# Altium schematic sheet 信号调理电路.SchDoc(信号调理电路)
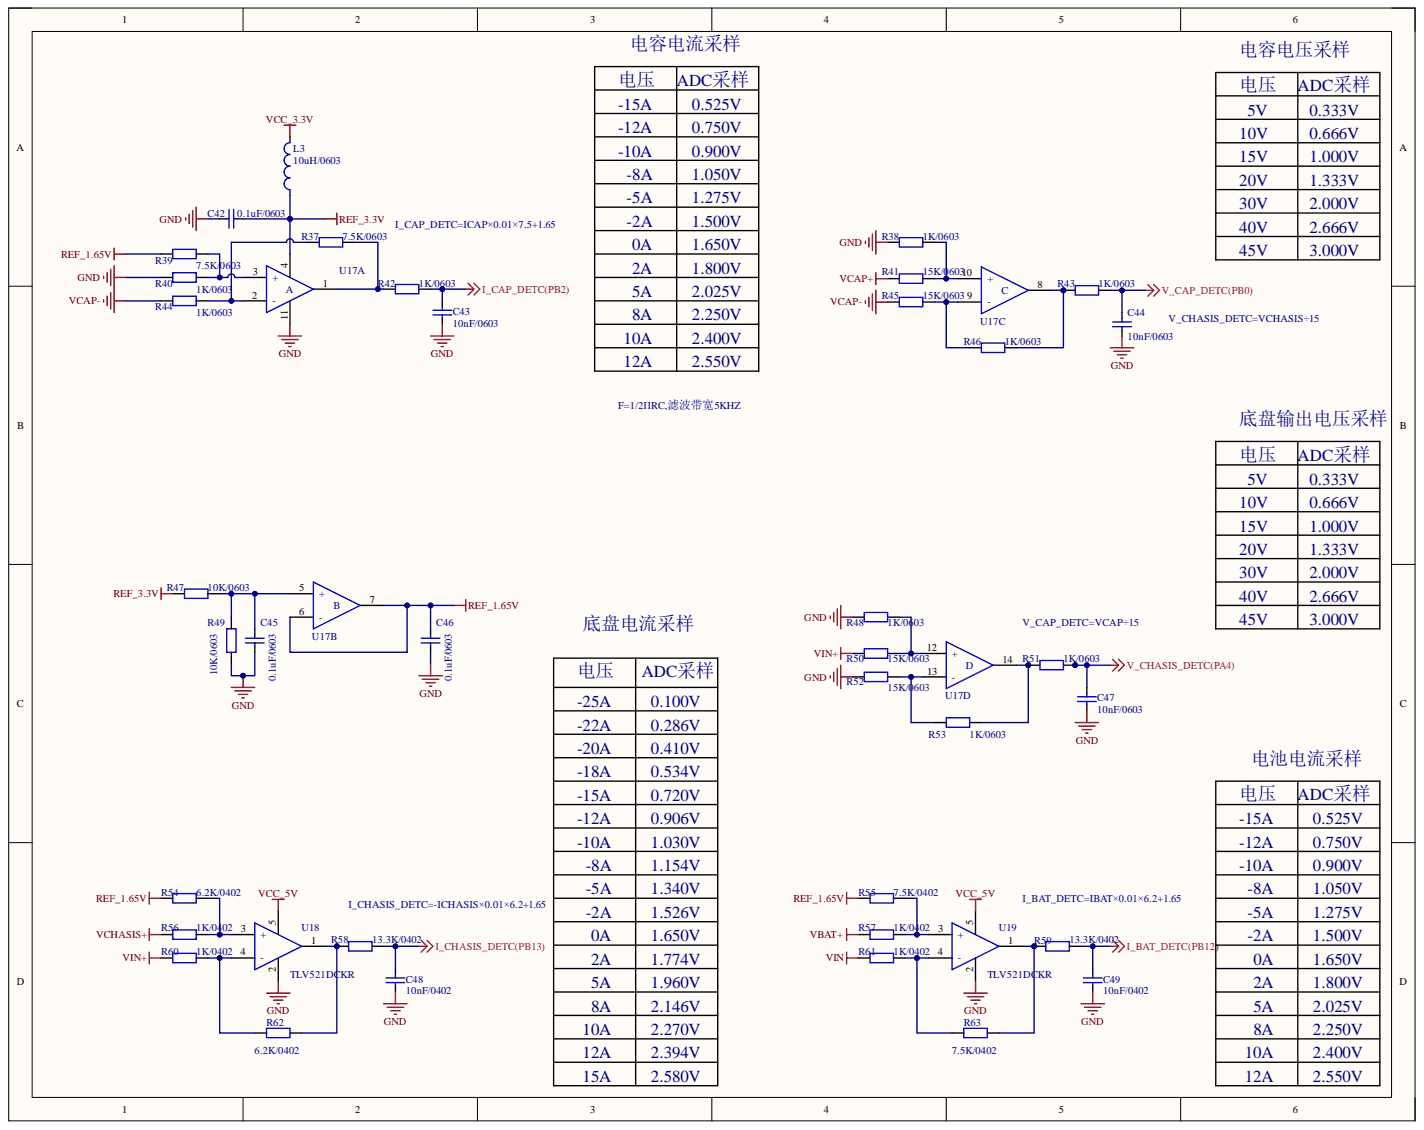
[diagram p0: 信号调理电路.SchDoc]

## Parts
C42  0.1uF  value 0.1uF/0603  [CAP_0603]
C43  10nF  value 10nF/0603  [CAP_0603]
C44  10nF  value 10nF/0603  [CAP_0603]
C45  0.1uF  value 0.1uF/0603  [CAP_0603]
C46  0.1uF  value 0.1uF/0603  [CAP_0603]
C47  10nF  value 10nF/0603  [CAP_0603]
C48  10nF  value 10nF/0402  [CAP_0402]
C49  10nF  value 10nF/0402  [CAP_0402]
L3  10uH  value 10uH/0603  [IND_0603]
R37  7.5K  value 7.5K/0603  [RES_0603]
R38  1K  value 1K/0603  [RES_0603]
R39  7.5K  value 7.5K/0603  [RES_0603]
R40  1K  value 1K/0603  [RES_0603]
R41  15K  value 15K/0603  [RES_0603]
R42  1K  value 1K/0603  [RES_0603]
R43  1K  value 1K/0603  [RES_0603]
R44  1K  value 1K/0603  [RES_0603]
R45  15K  value 15K/0603  [RES_0603]
R46  1K  value 1K/0603  [RES_0603]
R47  10K  value 10K/0603  [RES_0603]
R48  1K  value 1K/0603  [RES_0603]
R49  10K  value 10K/0603  [RES_0603]
R50  15K  value 15K/0603  [RES_0603]
R51  1K  value 1K/0603  [RES_0603]
R52  15K  value 15K/0603  [RES_0603]
R53  1K  value 1K/0603  [RES_0603]
R54  6.2K  value 6.2K/0402  [RES_0402]
R55  7.5K  value 7.5K/0402  [RES_0402]
R56  1K  value 1K/0402  [RES_0402]
R57  1K  value 1K/0402  [RES_0402]
R58  13.3K  value 13.3K/0402  [RES_0402]
R59  13.3K  value 13.3K/0402  [RES_0402]
R60  1K  value 1K/0402  [RES_0402]
R61  1K  value 1K/0402  [RES_0402]
R62  6.2K  value 6.2K/0402  [RES_0402]
R63  7.5K  value 7.5K/0402  [RES_0402]
U17  TLV2374  [SOP-14]  (value not drawn)  (parts A,B,C,D)
U18  TLV521DCKR  [SC-70-5_L2.1-W1.3-P0.65-LS2.1-BR]
U19  TLV521DCKR  [SC-70-5_L2.1-W1.3-P0.65-LS2.1-BR]

## Nets
GND: C42.1 C43.1 C44.1 C45.1 C46.1 C47.1 C48.1 C49.1 R38.1 R40.1 R48.1 R49.1 R52.1 U17.11(A) U18.2 U19.2
I_BAT_DETC(PB12): C49.2 R59.2
I_CAP_DETC(PB2): C43.2 R42.2
I_CHASIS_DETC(PB13): C48.2 R58.2
REF_1.65V: C46.2 R39.1 R54.1 R55.1 U17.6(-) U17.7(B)
REF_3.3V: C42.2 L3.1 R47.1 U17.4
V_CAP_DETC(PB0): C44.2 R43.2
V_CHASIS_DETC(PA4): C47.2 R51.2
VBAT+: R57.1
VCAP+: R41.1
VCAP-: R44.1 R45.1
VCC_3.3V: L3.2
VCC_5V: U18.5 U19.5
VCHASIS+: R56.1
VIN: R61.1
VIN+: R50.1 R60.1
NetC45_2: C45.2 R47.2 R49.2 U17.5(+)
NetR37_1: R37.1 R44.2 U17.2(-)
NetR37_2: R37.2 R42.1 U17.1
NetR38_2: R38.2 R41.2 U17.10(+)
NetR39_2: R39.2 R40.2 U17.3(+)
NetR43_1: R43.1 R46.2 U17.8
NetR45_2: R45.2 R46.1 U17.9(-)
NetR48_2: R48.2 R50.2 U17.12(+)
NetR51_1: R51.1 R53.2 U17.14(D)
NetR52_2: R52.2 R53.1 U17.13(-)
NetR54_2: R54.2 R56.2 U18.3(+)
NetR55_2: R55.2 R57.2 U19.3(+)
NetR58_1: R58.1 R62.2 U18.1
NetR59_1: R59.1 R63.2 U19.1
NetR60_2: R60.2 R62.1 U18.4(-)
NetR61_2: R61.2 R63.1 U19.4(-)

## Library data of the parts
C42-C49 = Cap_0603: Capacitor; Component Kind: Standard; Library Name: LIN_Library .SchLib
L3 = Ind_0603: Inductor; Component Kind: Standard; Library Name: LIN_Library .SchLib
R37-R63 = Res_0603: Resistor; Component Kind: Standard; Library Name: LIN_Library .SchLib
U17 = TLV2374: 多通道运放; Component Kind: Standard; Library Name: LIN_Library .SchLib
U18 U19 = TLV521DCKR: 单通道运放; Component Kind: Standard; Library Name: LIN_Library .SchLib
